# Altium schematic sheet DMP_SEM_SDCARD.SchDoc(DMP_SEM_SDCARD)
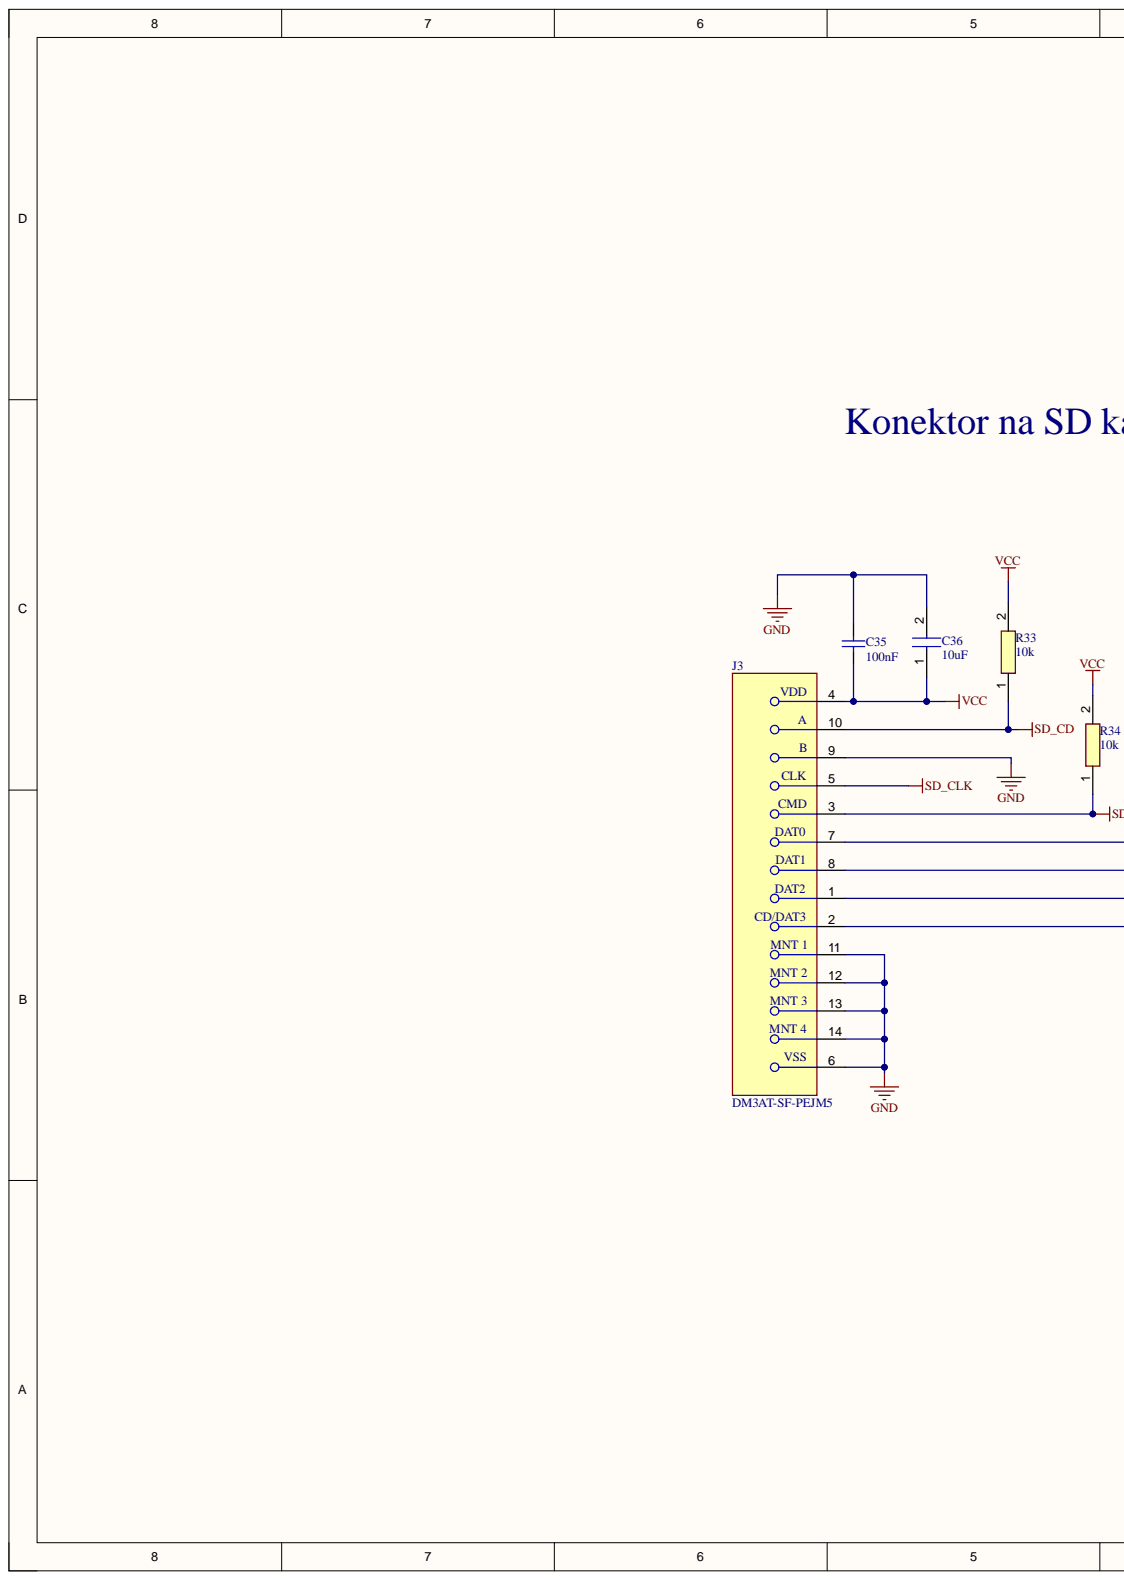
[diagram p0: DMP_SEM_SDCARD.SchDoc, left]
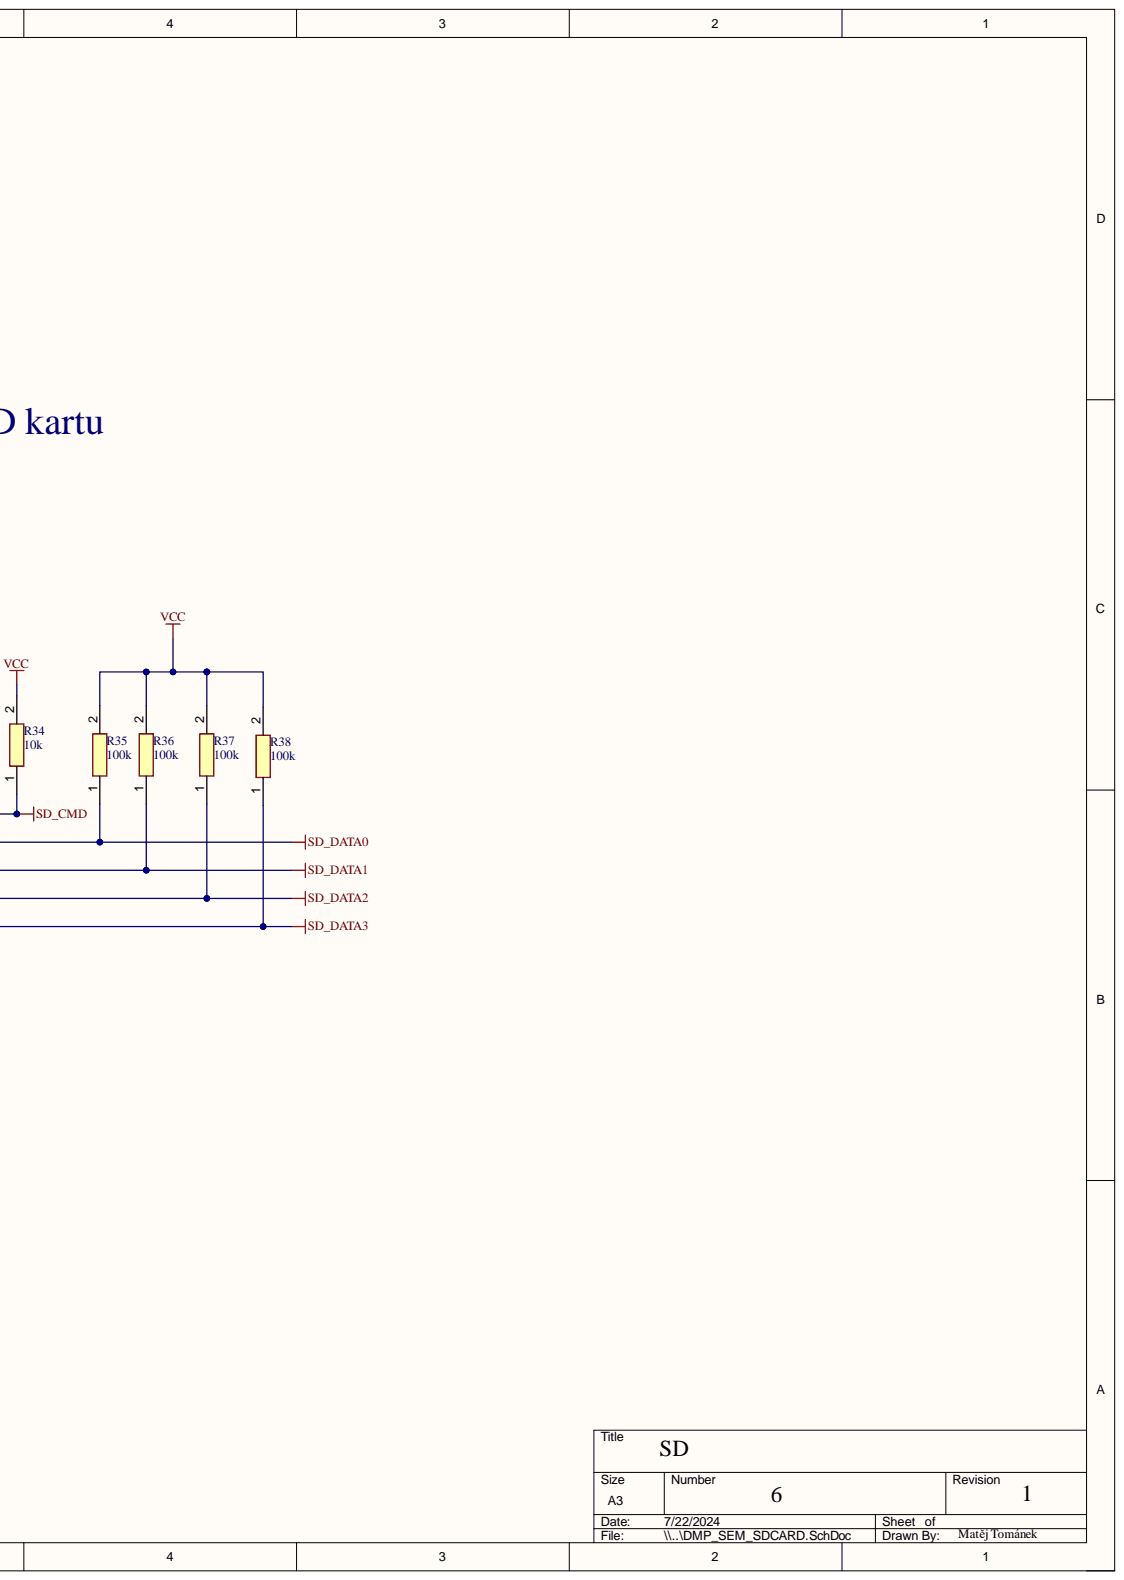
[diagram p1: DMP_SEM_SDCARD.SchDoc, right]

## Parts
C35  100nF  [CAPC1050X55X23ML05T13]
C36  10uF  [CAPC1608X95N]
J3  DM3AT-SF-PEJM5  [FP-DM3AT-SF-PEJM5-MFG]
R33  10k  [RESC1608X50N]
R34  10k  [RESC1608X50N]
R35  100k  [ERJ2RKD1004X]
R36  100k  [ERJ2RKD1004X]
R37  100k  [ERJ2RKD1004X]
R38  100k  [ERJ2RKD1004X]

## Nets
GND: C35.1 C36.2 J3.6 J3.9 J3.11 J3.12 J3.13 J3.14
SD_CD: J3.10 R33.1
SD_CLK: J3.5
SD_CMD: J3.3 R34.1
SD_DATA0: J3.7 R35.1
SD_DATA1: J3.8 R36.1
SD_DATA2: J3.1 R37.1
SD_DATA3: J3.2 R38.1
VCC: C35.2 C36.1 J3.4 R33.2 R34.2 R35.2 R36.2 R37.2 R38.2

## Library data of the parts
C35 = CMP-2000-05439-1: Chip Capacitor, 100 nF, +/- 10%, 16 V, -55 to 125 degC, 0402 (1005 Metric), RoHS, Tape and ReelCL05B104KO5NNNC; Manufacturer: Samsung Electro-Mechanics; Capacitance: 100000pF; Dielectric: X7R; Rated Voltage: 16V; Case Size/Dimension: 0402; Component Kind: Standard; Datasheet URL: https://datasheet.ciiva.com/29198/458718-da-01-en-vs-kondensator-0402-29198423.pdf; Datasheet Version: Nov-10; Library Name: Altium Content Vault; Manufacturer URL: http://www.samsungsem.com/global/index.jsp; Tolerance (%): ±10%
C36 = CL10A106KO8NQNC: Capacitor 10uF CL10A106KO8NQNC; Manufacturer_Name: SAMSUNG; Arrow Part Number: CL10A106KO8NQNC; Arrow Price/Stock: https://www.arrow.com/en/products/cl10a106ko8nqnc/samsung-electro-mechanics; Component Kind: Standard; ComponentLink1Description: shop; ComponentLink1URL: https://cz.mouser.com/ProductDetail/Samsung-Electro-Mechanics/CL10A106KO8NQNC?qs=xZ%2FP%252Ba9zWqY%252BA5vKSHboXg%3D%3D; Datasheet Link: https://product.samsungsem.com/mlcc/CL10A106KO8NQN.do; Height: 0.95mm; Library Name: SamacSys.SchLib; Manufacturer_Part_Number: CL10A106KO8NQNC
J3 = CMP-2000-05150-2: CONN MICRO SD CARD PUSH-PUSH R/A; Connector Type: Connector; Current Rating: 500mA; Gender: Female; Max Voltage Rating (AC): 125V; Number of Contacts: 8; Pitch: 1.1mm; Component Kind: Standard; Contact Material: Copper Alloy; Contact Plating: Gold; Depth: 15.95mm; Flammability Rating: UL94 V-0; Height: 1.68mm; Housing Material: Polymer; Lead Free: Lead Free; Length: 15.95mm; Library Name: Altium Content Vault; Max Current Rating: 500mA; Max Operating Temperature: 85°C; Min Operating Temperature: -25°C; Mount: Surface Mount; Number of Positions: 8; Number of Rows: 1; Orientation: Right Angle; Package Quantity: 1; Packaging: Tape and Reel; Radiation Hardening: No; REACH SVHC: No SVHC; Termination: SMD/SMT; Voltage Rating: 125V; Width: 13.85mm
R33 R34 = CRCW060310K0FKEA: Resistor CRCW060310K0FKEA; Manufacturer_Name: Vishay; Arrow Part Number: CRCW060310K0FKEA; Arrow Price/Stock: https://www.arrow.com/en/products/crcw060310k0fkea/vishay?region=nac; Component Kind: Standard; ComponentLink1Description: shop; ComponentLink1URL: https://cz.mouser.com/ProductDetail/Vishay-Dale/CRCW060310K0FKEA?qs=pAO1Z172M84BVnhDjtnsoQ%3D%3D; Datasheet Link: http://www.vishay.com/docs/20035/dcrcwe3.pdf; Height: 0.5mm; Manufacturer_Part_Number: CRCW060310K0FKEA; Mouser Part Number: 71-CRCW0603-10K-E3; Mouser Price/Stock: https://www.mouser.co.uk/ProductDetail/Vishay-Dale/CRCW060310K0FKEA?qs=pAO1Z172M84BVnhDjtnsoQ%3D%3D
R35-R38 = ERJ-2RKF1003X: Resistor ERJ-2RKF1003X; Manufacturer_Name: Panasonic; Arrow Part Number: ERJ-2RKF1003X; Arrow Price/Stock: https://www.arrow.com/en/products/erj-2rkf1003x/panasonic?region=europe; Component Kind: Standard; Datasheet Link: https://industrial.panasonic.com/cdbs/www-data/pdf/RDA0000/AOA0000C86.pdf; Height: 0.4mm; Manufacturer_Part_Number: ERJ-2RKF1003X; Mouser Part Number: 667-ERJ-2RKF1003X; Mouser Price/Stock: https://www.mouser.co.uk/ProductDetail/Panasonic/ERJ-2RKF1003X?qs=H7k1u0Mp9JQnXoBKdf15Qg%3D%3D
